# Altium schematic sheet Interface.SchDoc
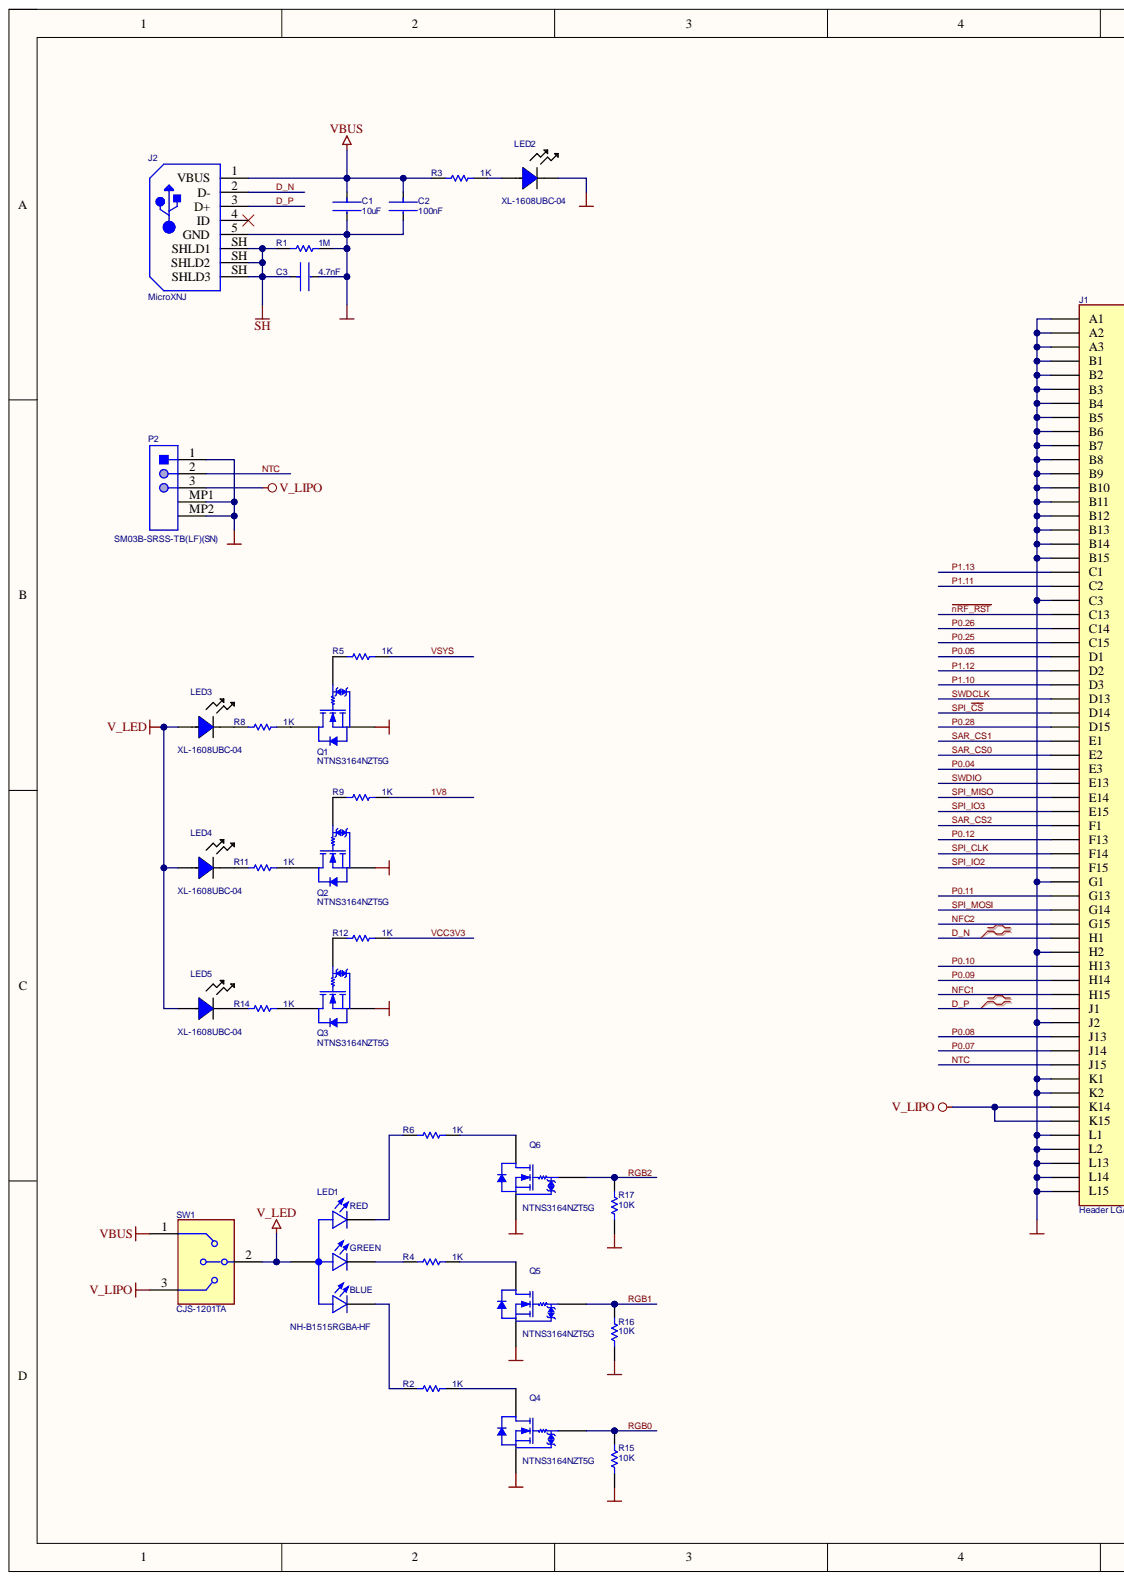
[diagram p0: Interface.SchDoc, left]
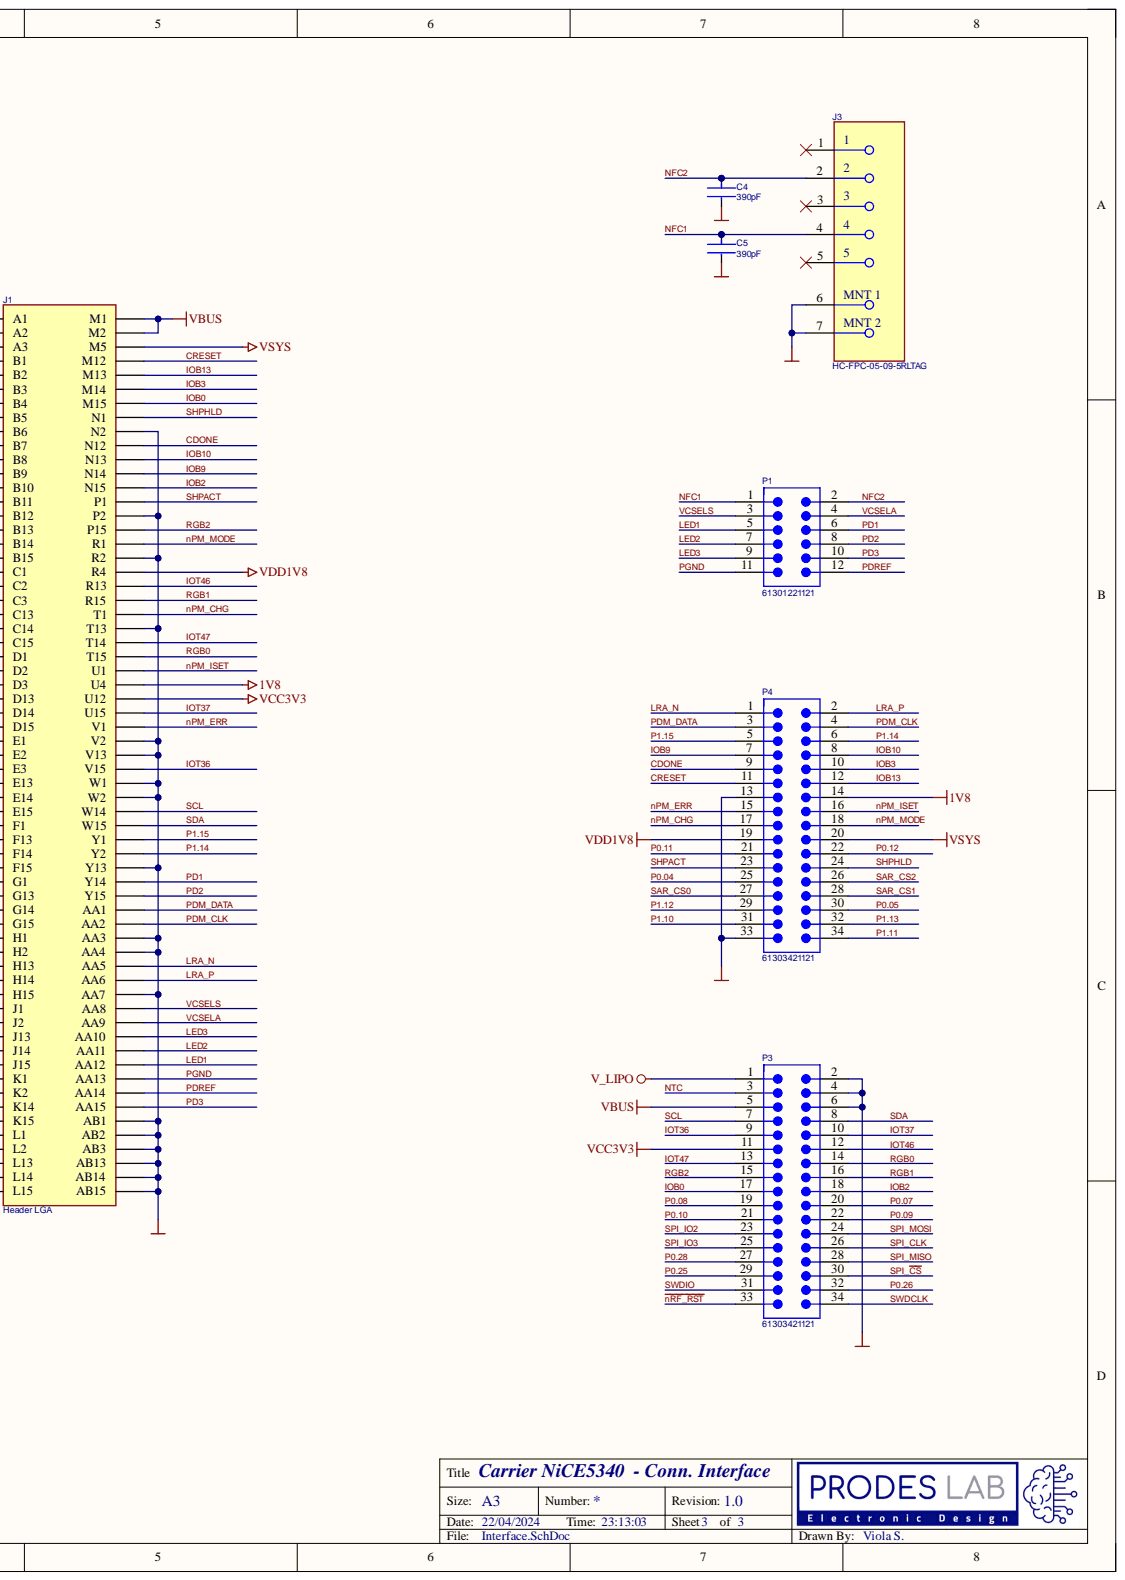
[diagram p1: Interface.SchDoc, right]

## Parts
C1  value 10uF  [FP-GRM155-0_2-IPC_C]  (hidden comment: GRM155R61A106ME11D)
C2  value 100nF  [FP-CC0402-CA-IPC_B]  (hidden comment: CC0402KRX5R6BB104)
C3  value 4.7nF  [FP-C0402-BB-IPC_B]  (hidden comment: C0402C472K5RACTU)
C4  value 390pF  [FP-C0402-BB-IPC_C]  (hidden comment: C0402C391J5RACTU)
C5  value 390pF  [FP-C0402-BB-IPC_C]  (hidden comment: C0402C391J5RACTU)
J1  Header LGA  [LGA HEADER 120 - Carrier]  (no BOM)
J2  MicroXNJ  [MicroXNJ]
J3  HC-FPC-05-09-5RLTAG  [HC-FPC-05-09-5RLTAG]
LED1  NH-B1515RGBA-HF  [NH-B1515RGBA-HF]
LED2  XL-1608UBC-04  [XL-1608UBC-04]
LED3  XL-1608UBC-04  [XL-1608UBC-04]
LED4  XL-1608UBC-04  [XL-1608UBC-04]
LED5  XL-1608UBC-04  [XL-1608UBC-04]
P1  61301221121  [61301221121]
P2  SM03B-SRSS-TB(LF)(SN)  [JST-SM03B-SRSS-TB_V]
P3  61303421121  [61303421121]
P4  61303421121  [61303421121]
Q1  NTNS3164NZT5G  [ONSC-SOT-883-3-506CB_V]
Q2  NTNS3164NZT5G  [ONSC-SOT-883-3-506CB_V]
Q3  NTNS3164NZT5G  [ONSC-SOT-883-3-506CB_V]
Q4  NTNS3164NZT5G  [ONSC-SOT-883-3-506CB_V]
Q5  NTNS3164NZT5G  [ONSC-SOT-883-3-506CB_V]
Q6  NTNS3164NZT5G  [ONSC-SOT-883-3-506CB_V]
R1  value 1M  [RESC1005X40X25NL05T10]  (hidden comment: RC0402FR-071ML)
R2  value 1K  [RESC1005X40X25LL05T10]  (hidden comment: MCMR04X1001FTL)
R3  value 1K  [RESC1005X40X25NL05T10]  (hidden comment: MCMR04X1001FTL)
R4  value 1K  [RESC1005X40X25LL05T10]  (hidden comment: MCMR04X1001FTL)
R5  value 1K  [RESC1005X40X25LL05T10]  (hidden comment: MCMR04X1001FTL)
R6  value 1K  [RESC1005X40X25LL05T10]  (hidden comment: MCMR04X1001FTL)
R8  value 1K  [RESC1005X40X25LL05T10]  (hidden comment: MCMR04X1001FTL)
R9  value 1K  [RESC1005X40X25LL05T10]  (hidden comment: MCMR04X1001FTL)
R11  value 1K  [RESC1005X40X25LL05T10]  (hidden comment: MCMR04X1001FTL)
R12  value 1K  [RESC1005X40X25LL05T10]  (hidden comment: MCMR04X1001FTL)
R14  value 1K  [RESC1005X40X25LL05T10]  (hidden comment: MCMR04X1001FTL)
R15  value 10K  [RESC1005X40X25LL05T10]  (hidden comment: MCMR04X1002FTL)
R16  value 10K  [RESC1005X40X25LL05T10]  (hidden comment: MCMR04X1002FTL)
R17  value 10K  [RESC1005X40X25LL05T10]  (hidden comment: MCMR04X1002FTL)
SW1  CJS-1201TA  [FP-CJS-1201TA-MFG]

## Nets
GND: C1.2 C2.2 C3.2 C4.2 C5.2 J1.A1 J1.A2 J1.A3 J1.AA3 J1.AA4 J1.AA7 J1.AB1 J1.AB2 J1.AB3 J1.AB13 J1.AB14 J1.AB15 J1.B1 J1.B2 J1.B3 J1.B4 J1.B5 J1.B6 J1.B7 J1.B8 J1.B9 J1.B10 J1.B11 J1.B12 J1.B13 J1.B14 J1.B15 J1.C3 J1.G1 J1.H2 J1.J2 J1.K1 J1.K2 J1.L1 J1.L2 J1.L13 J1.L14 J1.L15 J1.N2 J1.P2 J1.R2 J1.T13 J1.V2 J1.V13 J1.W1 J1.W2 J1.Y13 J2.5(GND) J3.6 J3.7 LED2.2 P2.1 P2.MP1 P2.MP2 P3.2 P3.4 P3.6 P4.13 P4.33 Q1.2 Q2.2 Q3.2 Q4.2 Q5.2 Q6.2 R1.2 R15.1 R16.1 R17.1
SH: C3.1 J2.SH(SHLD3) R1.1
V_LED: LED1.2 LED3.1 LED4.1 LED5.1 SW1.2
V_LIPO: J1.K14 J1.K15 P2.3 P3.1 SW1.3
VBUS: C1.1 C2.1 J1.M1 J1.M2 J2.1(VBUS) P3.5 R3.1 SW1.1
VDD1V8: J1.R4 P4.19
1V8: J1.U4 P4.14 R9.2
~{nRF_RST}: J1.C13 P3.33
CDONE: J1.N12 P4.9
CRESET: J1.M12 P4.11
D_N: J1.H1 J2.2(D-)
D_P: J1.J1 J2.3(D+)
IOB0: J1.M15 P3.17
IOB2: J1.N15 P3.18
IOB3: J1.M14 P4.10
IOB9: J1.N14 P4.7
IOB10: J1.N13 P4.8
IOB13: J1.M13 P4.12
IOT36: J1.V15 P3.9
IOT37: J1.U15 P3.10
IOT46: J1.R13 P3.12
IOT47: J1.T14 P3.13
LED1: J1.AA12 P1.5
LED2: J1.AA11 P1.7
LED3: J1.AA10 P1.9
LRA_N: J1.AA5 P4.1
LRA_P: J1.AA6 P4.2
NFC1: C5.1 J1.H15 J3.4 P1.1
NFC2: C4.1 J1.G15 J3.2 P1.2
nPM_CHG: J1.T1 P4.17
nPM_ERR: J1.V1 P4.15
nPM_ISET: J1.U1 P4.16
nPM_MODE: J1.R1 P4.18
NTC: J1.J15 P2.2 P3.3
P0.04: J1.E3 P4.25
P0.05: J1.D1 P4.30
P0.07: J1.J14 P3.20
P0.08: J1.J13 P3.19
P0.09: J1.H14 P3.22
P0.10: J1.H13 P3.21
P0.11: J1.G13 P4.21
P0.12: J1.F13 P4.22
P0.25: J1.C15 P3.29
P0.26: J1.C14 P3.32
P0.28: J1.D15 P3.27
P1.10: J1.D3 P4.31
P1.11: J1.C2 P4.34
P1.12: J1.D2 P4.29
P1.13: J1.C1 P4.32
P1.14: J1.Y2 P4.6
P1.15: J1.Y1 P4.5
PD1: J1.Y14 P1.6
PD2: J1.Y15 P1.8
PD3: J1.AA15 P1.10
PDM_CLK: J1.AA2 P4.4
PDM_DATA: J1.AA1 P4.3
PDREF: J1.AA14 P1.12
PGND: J1.AA13 P1.11
RGB0: J1.T15 P3.14 Q4.1 R15.2
RGB1: J1.R15 P3.16 Q5.1 R16.2
RGB2: J1.P15 P3.15 Q6.1 R17.2
SAR_CS0: J1.E2 P4.27
SAR_CS1: J1.E1 P4.28
SAR_CS2: J1.F1 P4.26
SCL: J1.W14 P3.7
SDA: J1.W15 P3.8
SHPACT: J1.P1 P4.23
SHPHLD: J1.N1 P4.24
SPI_~{CS}: J1.D14 P3.30
SPI_CLK: J1.F14 P3.26
SPI_IO2: J1.F15 P3.23
SPI_IO3: J1.E15 P3.25
SPI_MISO: J1.E14 P3.28
SPI_MOSI: J1.G14 P3.24
SWDCLK: J1.D13 P3.34
SWDIO: J1.E13 P3.31
VCC3V3: J1.U12 P3.11 R12.2
VCSELA: J1.AA9 P1.4
VCSELS: J1.AA8 P1.3
VSYS: J1.M5 P4.20 R5.2
NetLED1_1: LED1.1 R6.1
NetLED1_3: LED1.3 R2.1
NetLED1_4: LED1.4 R4.1
NetLED2_1: LED2.1 R3.2
NetLED3_2: LED3.2 R8.1
NetLED4_2: LED4.2 R11.1
NetLED5_2: LED5.2 R14.1
NetQ1_1: Q1.1 R5.1
NetQ1_3: Q1.3 R8.2
NetQ2_1: Q2.1 R9.1
NetQ2_3: Q2.3 R11.2
NetQ3_1: Q3.1 R12.1
NetQ3_3: Q3.3 R14.2
NetQ4_3: Q4.3 R2.2
NetQ5_3: Q5.3 R4.2
NetQ6_3: Q6.3 R6.2

Not connected: J2 4(ID); J3 1 3 5

## Library data of the parts
C1 = CMP-06035-026070-1: Chip Multilayer Ceramic Capacitors for General Purpose, 0402, 10uF, X5R, 15%, 20%, 10V; Component Kind: Standard; Library Name: Altium Content Vault
C2 = CMP-1034-02065-3: CAP CER 0.1UF 10V X5R 0402; Dissipation Factor: 5%; Insulation Resistance: 10GR; Tolerance: 10%; Case Code (Imperial): 0402; Case Code (Metric): 1005; Case/Package: 0402; Component Kind: Standard; Depth: 0.5mm; Dielectric: X5R; Halogen Free: Halogen Free; Height: 0.5mm; Lead Free: Lead Free; Length: 1mm; Library Name: Altium Content Vault; Max Operating Temperature: 85°C; Min Operating Temperature: -55°C; Mount: Surface Mount; Number of Pins: 2; Package Quantity: 1; Packaging: Tape and Reel; Radiation Hardening: No; REACH SVHC: No SVHC; RoHS Compliant: Yes; Series: CC; Termination: SMD/SMT; Thickness: 0.022inch; Voltage Rating: 10V; Voltage Rating (DC): 10V; Width: 0.02inch
C3 = CMP-2008-03214-2: CAP CER 4700PF 50V 10% X7R 0402; Manufacturer: Kemet; Capacitance: 4,700 pF; Material: Ceramic; Temperature Coefficient: 15%; Tolerance: 0.1; Automotive: No; Category: Cap; Component Kind: Standard; ComponentLink2Description: Datasheet; ComponentLink2URL: https://content.kemet.com/datasheets/KEM_C1002_X7R_SMD.pdf; Device Class L1: Passive Components; Device Class L2: Capacitors; Device Class L3: Ceramic Capacitors; Digikey Description: CAP CER 4700PF 50V 10% X7R 0402; Height: 0.55mm; Ipc Land Pattern Name: CAPC10050X50; Lead Free: Yes; Library Name: Altium Content Vault; Manufacturer Part Number: C0402C472K5RACTU; Mouser Description: Cap Ceramic 0.0047uF 50V X7R 10% SMD 0402 125°C Paper T/R; Octopart: https://octopart.com/c0402c472k5ractu-kemet-104818; Package: C0402-BB; RoHS: TRUE; Temperature: -55°C to +125°C; Temperature Characteristic: X7R; Temperature Range High: +125°C; Temperature Range Low: -55°C; Voltage Rating: 50 V
C4 C5 = CMP-2008-03187-2: CAP CER 390PF 50V X7R 0402; Manufacturer: Kemet; Material: Ceramic; Temperature Coefficient: 15%; Tolerance: 0.05; Automotive: No; Capacitance: 390 pF; Category: Cap; Component Kind: Standard; ComponentLink2Description: Datasheet; ComponentLink2URL: https://content.kemet.com/datasheets/KEM_C1002_X7R_SMD.pdf; Device Class L1: Passive Components; Device Class L2: Capacitors; Device Class L3: Ceramic Capacitors; Digikey Description: CAP CER 390PF 50V X7R 0402; Height: 0.55mm; Ipc Land Pattern Name: CAPC10050X50; Lead Free: Yes; Library Name: Altium Content Vault; Manufacturer Part Number: C0402C391J5RACTU; Mouser Description: Multilayer Ceramic Capacitors MLCC - SMD/SMT 50volts 390pF X7R 5%; Octopart: https://octopart.com/c0402c391j5ractu-kemet-10533012; Package: C0402-BB; RoHS: TRUE; Temperature: -55°C to +125°C; Temperature Characteristic: X7R; Temperature Range High: +125°C; Temperature Range Low: -55°C; Voltage Rating: 50 V
J1 = LGA HEADER 120: Stamp footprint 30pin 1.5mm pitch; Library Name: NiCE5340.SchLib; Value: Header LGA
J2 = MicroXNJ: 1A USB 2.0 1 Surface Mount，Right Angle 5P Female -20℃~+85℃ Micro-B SMD USB Connectors ROHS; Manufacturer: SHOU HAN; Gender: Receptacle; Number of Ports: 1; Voltage Rating: 30VAC; Component Kind: Standard; Contact Material: CopperAlloy; Contact Plating: Gold; Current Rating: 1A; Library Name: NiCE5340.SchLib; Mounting Style: SMD/SMT; Package Description: 5-Pin Surface Mount Device, Pitch 0.65 mm; PartNumber: MicroXNJ; Product: MicroUSBTypeBConnectors; Product Category: USBConnectors; Standard: USB; Termination Style: SolderTail
J3 = HC-FPC-05-09-5RLTAG: SMD,P=0.5mm,Surface Mount，Right Angle; Component Kind: Standard; Library Name: NiCE5340.SchLib
LED1 = NH-B1515RGBA-HF: -30℃~+85℃ Common anode RGB 110° 0606 Light Emitting Diodes (LED) ROHS; Component Kind: Standard; Library Name: NiCE5340.SchLib; MP: NH-B1515RGBA-HF; Price: None; Value: NH-B1515RGBA-HF
LED2-LED5 = XL-1608UBC-04: 30℃~+85℃ Positive post 460nm~469nm Blue 120° 80mW 0603 Light Emitting Diodes (LED) ROHS; Component Kind: Standard; Library Name: NiCE5340.SchLib; Manufacturer_Part_Number: XL-1608UBC-04
P1 = CMP-1502-01088-3: NexarPartId: 32855540; Connector Type: Male Pin; Contact Resistance: 20mΩ; Current Rating: 3A; Gender: Male; Number of Contacts: 12; Operating Supply Voltage: 250V; Pitch: 2.54mm; Color: Black; Component Kind: Standard; Contact Material: Copper; Contact Mating Length: 5.9944mm; Contact Plating: Gold; Height: 8.89mm; Lead Pitch: 2.54mm; Library Name: Altium Content Vault; Max Operating Temperature: 105°C; Min Operating Temperature: -40°C; Mount: Through Hole; Number of Pins: 12; Number of Positions: 12; Number of Rows: 2; Orientation: Vertical; Packaging: Bulk; REACH SVHC: Unknown; RoHS: Compliant; Row Spacing: 2.54mm; Termination: Solder; Voltage Rating: 250V
P2 = CMP-2000-07077-1: Male Header, Pitch 1 mm, 1 x 3 Position, Height 2.9 mm, -25 to 85 degC, RoHS, Tape and Reel; Manufacturer: JST; No of Positions: 3; Pitch: 1mm; Style/Orientation: RightAngleHeader/Plug; Component Kind: Standard; Datasheet URL: https://datasheet.ciiva.com/26894/getdatasheetpartid-708885-26894671.pdf; Datasheet Version: 11/2010; Library Name: Altium Content Vault; Manufacturer URL: http://www.jst.com/home2.html; Mounting Technology: SurfaceMount; Package Description: Male Header, Pitch 1 mm, 1 x 3 Position, Height 2.9 mm; Package Reference: SM03B-SRSS-TB; Termination: Crimp; Type: ShroudedHeader
P3 P4 = CMP-1502-01127-2: NexarPartId: 32855572; Connector Type: Male Pin; Contact Resistance: 20mΩ; Current Rating: 3A; Gender: Male; Number of Contacts: 34; Operating Supply Voltage: 250V; Pitch: 2.54mm; Color: Black; Component Kind: Standard; Contact Material: Copper; Contact Mating Length: 5.9944mm; Contact Plating: Gold; Lead Pitch: 2.54mm; Library Name: Altium Content Vault; Mount: Through Hole; Number of Pins: 34; Number of Positions: 34; Number of Rows: 2; Orientation: Vertical; Packaging: Bulk; REACH SVHC: Unknown; RoHS: Compliant; Row Spacing: 2.54mm; Termination: Solder
Q1-Q6 = CMP-1058-00845-1: Small Signal MOSFET, 20 V, 361 mA, Single N-Channel, 3-Pin SOT-883, Pb-Free, Tape and Reel; Manufacturer: On Semiconductor; Channel Polarity: N Channel; Ciss Typ (pF): 24; Coss Typ (pF): 5; Crss Typ (pF): 3.4; ID Max (A): 0.361; V(BR)DSS Min (V): 20; VGS Max (V): 8; VGS(th) Max (V): 1; Component Kind: Standard; ComponentLink1Description: Manufacturer URL; ComponentLink1URL: http://www.onsemi.com/; ComponentLink2Description: Datasheet; ComponentLink2URL: http://www.onsemi.com/pub_link/Collateral/NTNS3164NZ-D.PDF; ComponentLink3Description: Package Specification; ComponentLink3URL: http://www.onsemi.com/pub_link/Collateral/506CB.PDF; Configuration: Single; DatasheetVersion: Rev. 0; Library Name: Altium Content Vault; Mounting Technology: Surface Mount; Package Type: SOT-883; PackageDescription: 3-Pin Small Outline Transistor, Body 1.0125 x 0.6125 mm, Pitch 0.35 mm; PackageReference: SOT-883-3-506CB; PackageVersion: Rev. A, 03/2012; Packing: Tape and Reel; PartNumber: NTNS3164NZT5G; RoHS: Pb-Free
R1 = CMP-2002-07490-1: Manufacturer: Yageo / Phycomp; Component Kind: Standard; Library Name: Altium Content Vault; Part Number: RC0402FR-071ML
R2-R6 R8 R9 R11 R12 R14 = CMP-2002-06478-1: Manufacturer: Multicomp; Component Kind: Standard; Library Name: Altium Content Vault; Part Number: MCMR04X1001FTL
R15-R17 = CMP-2002-06479-1: Manufacturer: Multicomp; Component Kind: Standard; Library Name: Altium Content Vault; Part Number: MCMR04X1002FTL
SW1 = CJS-1201TA: SWITCH SLIDE SPDT 100MA 6V; Manufacturer: Copal Electronics Inc; Contact Resistance: 100mΩ; Operating Force: 5.9N; Standoff Height: 0mm; Automotive: No; Category: Switch; Component Kind: Standard; ComponentLink2Description: Datasheet; ComponentLink2URL: https://www.nidec-copal-electronics.com/e/catalog/switch/cjs.pdf; Contact Current Rating: 100mA; Device Class L1: Electromechanical; Device Class L2: Switches; Device Class L3: Slide Switches; Digikey Description: SWITCH SLIDE SPDT 100MA 6V; Height: 2.5mm; Lead Free: Yes; Library Name: NiCE5340.SchLib; Manufacturer Part Number: CJS-1201TA; Mount: Surface Mount; Octopart: https://octopart.com/cjs-1201ta-nidec+copal-87363567; Package: CJS-1201TA; RoHS: TRUE; Temperature: -40°C to +85°C; Temperature Range High: +85°C; Temperature Range Low: -40°C; Throw Configuration: SPDT; Voltage Rating Dc: 6V
